# Altium schematic sheet Master1.SchDoc
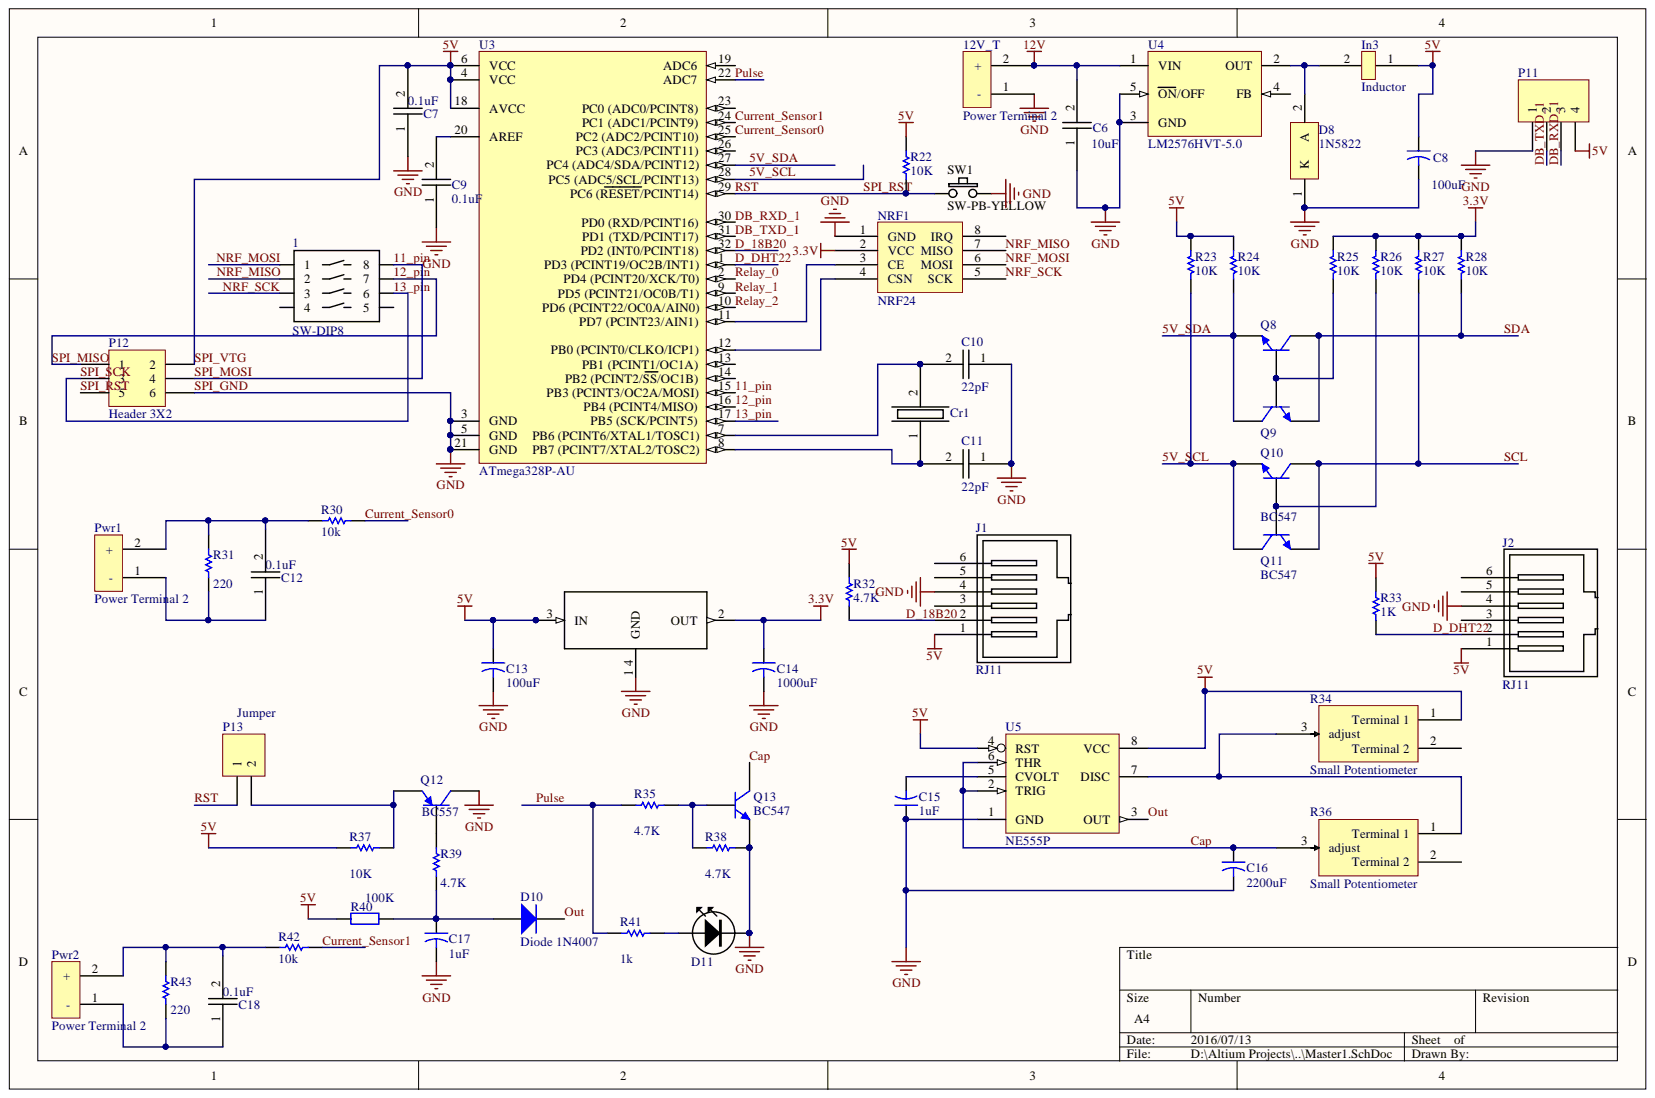
[diagram p0: Master1.SchDoc]

## Parts
1  SW-DIP8  [SW-DIP8]
2  AMS1117-3.3  [SOT-223_AMS1117-3.3(Primary)]  (value not drawn)
12V_T  Power Terminal 2  [PowerTerminal_2]
C6  value 10uF  [CAPNTO2.54-3.05x1.78A]  (hidden comment: Ceramic Capacitor)
C7  value 0.1uF  [CAPNTO2.54-3.05x1.78A]  (hidden comment: Ceramic Capacitor)
C8  value 100uF  [CAPR5-4X5]  (hidden comment: Cap2)
C9  value 0.1uF  [CAPNTO2.54-3.05x1.78A]  (hidden comment: Ceramic Capacitor)
C10  value 22pF  [CAPNTO2.54-3.05x1.78A]  (hidden comment: Ceramic Capacitor)
C11  value 22pF  [CAPNTO2.54-3.05x1.78A]  (hidden comment: Ceramic Capacitor)
C12  value 0.1uF  [CAPNTO2.54-3.05x1.78A]  (hidden comment: Ceramic Capacitor)
C13  value 100uF  [CAPR5-4X5]  (hidden comment: Cap2)
C14  value 1000uF  [CAPR5-4X5]  (hidden comment: Cap2)
C15  value 1uF  [CAPR5-4X5]  (hidden comment: Cap2)
C16  Cap2  value 2200uF  [CAPR5-4X5]
C17  value 1uF  [CAPR5-4X5]  (hidden comment: Cap2)
C18  value 0.1uF  [CAPNTO2.54-3.05x1.78A]  (hidden comment: Ceramic Capacitor)
Cr1  Crystal  [Crytal_HC49]  (value not drawn)
D8  1N5822  [ONSC-AXIAL_LEAD-2-267-05_V]
D10  Diode 1N4007  [DO-41]
D11  LED-GREEN-3MM  [LED-3MM-GREEN]  (value not drawn)
In3  Inductor  [Inductor]
J1  RJ11  [RJ11]
J2  RJ11  [RJ11]
NRF1  NRF24  [NRF24]
P11  Header 4  [HDR1X4]  (value not drawn)
P12  Header 3X2  [HDR2X3]
P13  Jumper  [HDR1X2]
Pwr1  Power Terminal 2  [PowerTerminal_2]
Pwr2  Power Terminal 2  [PowerTerminal_2]
Q8  BC547  [SOT54]  (value not drawn)
Q9  BC547  [SOT54]  (value not drawn)
Q10  BC547  [SOT54]
Q11  BC547  [SOT54]
Q12  BC557  [SOT54]
Q13  BC547  [SOT54]
R22  value 10K  [AXIAL-0.3]  (hidden comment: Res1)
R23  value 10K  [AXIAL-0.3]  (hidden comment: Res1)
R24  value 10K  [AXIAL-0.3]  (hidden comment: Res1)
R25  value 10K  [AXIAL-0.3]  (hidden comment: Res1)
R26  value 10K  [AXIAL-0.3]  (hidden comment: Res1)
R27  value 10K  [AXIAL-0.3]  (hidden comment: Res1)
R28  value 10K  [AXIAL-0.3]  (hidden comment: Res1)
R30  value 10k  [AXIAL-0.3]  (hidden comment: Res1)
R31  value 220  [AXIAL-0.3]  (hidden comment: Res1)
R32  value 4.7K  [AXIAL-0.3]  (hidden comment: Res1)
R33  value 1K  [AXIAL-0.3]  (hidden comment: Res1)
R34  Small Potentiometer  [Potentiometer]
R35  value 4.7K  [AXIAL-0.3]  (hidden comment: Res1)
R36  Small Potentiometer  [Potentiometer]
R37  value 10K  [AXIAL-0.3]  (hidden comment: Res1)
R38  value 4.7K  [AXIAL-0.3]  (hidden comment: Res1)
R39  value 4.7K  [AXIAL-0.3]  (hidden comment: Res1)
R40  value 100K  [AXIAL-0.4]  (hidden comment: Res2)
R41  value 1k  [AXIAL-0.3]  (hidden comment: Res1)
R42  value 10k  [AXIAL-0.3]  (hidden comment: Res1)
R43  value 220  [AXIAL-0.3]  (hidden comment: Res1)
SW1  SW-PB-YELLOW  [SW-PB-YELLOW-ROUND4]
U3  ATmega328P-AU  [32A_N]
U4  LM2576HVT-5.0  [T05A]
U5  NE555P  [P008]

## Nets
3.3V: 2.2 C14.1 NRF1.2(VCC) R25.2 R26.2 R27.2 R28.2
12V: 12V_T.2 C6.2 U4.1(VIN)
5V_SCL: Q10.1 Q11.3 R23.1 U3.28(PC5_(ADC5/SCL/PCINT13))
5V_SDA: Q8.1 Q9.3 R24.1 U3.27(PC4_(ADC4/SDA/PCINT12))
11_PIN: 1.8 P12.4 U3.15(PB3_(PCINT3/OC2A/MOSI))
12_PIN: 1.7 P12.1 U3.16(PB4_(PCINT4/MISO))
13_PIN: 1.6 P12.3 U3.17(PB5_(SCK/PCINT5))
CAP: C16.1 Q13.3 R36.3 U5.2(TRIG) U5.6(THR)
CURRENT_SENSOR0: R30.1 U3.25
CURRENT_SENSOR1: R42.1 U3.24(PC1_(ADC1/PCINT9))
D_18B20: J1.2 R32.2 U3.32(PD2_(INT0/PCINT18))
D_DHT22: J2.2 R33.2 U3.1(PD3_(PCINT19/OC2B/INT1))
DB_RXD_1: P11.3 U3.30(PD0_(RXD/PCINT16))
DB_TXD_1: P11.2 U3.31(PD1_(TXD/PCINT17))
NRF_MISO: 1.2 NRF1.7(MISO)
NRF_MOSI: 1.1 NRF1.6(MOSI)
NRF_SCK: 1.3 NRF1.5(SCK)
OUT: D10.2 U5.3(OUT)
PULSE: R35.1 R41.1 U3.22(ADC7)
RELAY_0: U3.2(PD4_(PCINT20/XCK/T0))
RELAY_1: U3.9(PD5_(PCINT21/OC0B/T1))
RELAY_2: U3.10(PD6_(PCINT22/OC0A/AIN0))
RST: P12.5 P13.1 R22.1 SW1.1 U3.29(PC6_(RESET/PCINT14))
SCL: Q10.3 Q11.1 R27.1
SDA: Q8.3 Q9.1 R28.1
SPI_GND: 12V_T.1 C6.1 C7.1 C8.2 C9.1 C10.1 C11.1 C13.2 C14.2 C15.1 C16.2 C17.2 D8.1 D11.K J1.4 J2.4 NRF1.1(GND) P11.1 P12.6 Q12.3 Q13.1 R38.2 SW1.2 U3.3(GND) U3.5(GND) U3.21(GND) U4.3(GND) U4.5(ON/OFF) U5.1(GND)
SPI_VTG: 2.3 C7.2 C8.1 C13.1 In3.1 J1.1 J2.1 P11.4 P12.2 R22.2 R23.2 R24.2 R32.1 R33.1 R34.1 R37.1 R40.1 U3.4(VCC) U3.6(VCC) U3.18(AVCC) U5.4(RST) U5.8(VCC)
NetC9_2: C9.2 U3.20(AREF)
NetC10_2: C10.2 Cr1.2 U3.7
NetC11_2: C11.2 Cr1.1 U3.8
NetC12_1: C12.1 Pwr1.1 R31.1
NetC12_2: C12.2 Pwr1.2 R30.2 R31.2
NetC15_2: C15.2 U5.5(CVOLT)
NetC17_1: C17.1 D10.1 R39.1 R40.2
NetC18_1: C18.1 Pwr2.1 R43.1
NetC18_2: C18.2 Pwr2.2 R42.2 R43.2
NetD8_2: D8.2 In3.2 U4.2(OUT)
NetD11_A: D11.A R41.2
NetNRF1_3: NRF1.3(CE) U3.11(PD7_(PCINT23/AIN1))
NetNRF1_4: NRF1.4(CSN) U3.12(PB0_(PCINT0/CLKO/ICP1))
NetP13_2: P13.2 Q12.1 R37.2
NetQ8_2: Q8.2 Q9.2 R25.1
NetQ10_2: Q10.2 Q11.2 R26.1
NetQ12_2: Q12.2 R39.2
NetQ13_2: Q13.2 R35.2 R38.1
NetR34_3: R34.3 R36.1 U5.7(DISC)

Not connected: 1 4 5; 2 1 4; J1 3 5 6; J2 3 5 6; NRF1 8(IRQ); R34 2; R36 2; U3 13(PB1_(PCINT1/OC1A)) 14(PB2_(PCINT2/SS/OC1B)) 19(ADC6) 23(PC0_(ADC0/PCINT8)) 26(PC3_(ADC3/PCINT11)); U4 4(FB)

## Library data of the parts
1 = SW-DIP8: 4 POLE DIP SWITCH; Component Kind: Standard; Library Name: vh_altium_library.intLib
C8 C13-C17 = Cap2: Capacitor; Component Kind: Standard; Library Name: Miscellaneous Devices.IntLib; PackageDescription: Capacitor; 2 Leads; PackageReference: CAPR5-4X5; Published: 23-Sep-2002; Signal Integrity: Cap; Simulation: CAP; Publisher: Altium Limited
D8 = 1N5822: Rectifier, 2-Pin Axial_Lead, Bulk Bag; Manufacturer: On Semiconductor; Configuration: Single; IFSM Max (A): 80; Note: 0; Component Kind: Standard; ComponentLink1Description: Manufacturer URL; ComponentLink1URL: http://www.onsemi.com/; ComponentLink2Description: Datasheet; ComponentLink2URL: http://www.onsemi.cn/pub_link/Collateral/1N5820-D.PDF; ComponentLink3Description: Package Specification; ComponentLink3URL: http://www.onsemi.com/pub_link/Collateral/267-05.PDF; DatasheetVersion: Rev. 10; Library Name: ON Semi Schottky Diodes & Schottky Rectifiers.IntLib; Mounting Technology: Through Hole; PackageDescription: 2-Pin, Axial Lead, Diameter 5.05 mm; PackageReference: AXIAL_LEAD-2-267-05; PackageVersion: Rev. G, 06/2000; Packing: Bulk Bag; PartNumber: 1N5822; RoHS: FALSE
D10 = Diode 1N4007: 1 Amp General Purpose Rectifier; Code_JEDEC: DO-204-AL; Component Kind: Standard; ComponentLink1Description: Manufacturer Link; ComponentLink1URL: http://www.fairchildsemi.com/; ComponentLink2Description: Datasheet; ComponentLink2URL: http://www.fairchildsemi.com/ds/1N%2F1N4001.pdf; DatasheetVersion: 1998; LatestRevisionDate: 17-Jul-2002; LatestRevisionNote: Re-released for DXP Platform.; Library Name: Miscellaneous Devices.IntLib; PackageDescription: Diode, Axial; Body 5.2 x 2.7 mm (LxDia. max), Lead Dia. 0.86 mm (max); PackageReference: DO-41; PackageVersion: Aug-1999; Published: 8-Jun-2000; Signal Integrity: 1N4007; Simulation: 1N4007; Publisher: Altium Limited
J1 J2 = RJ11: GENERIC RJ11 6 PIN CONNECTOR; Component Kind: Standard; Library Name: vh_altium_library.intLib
P11 = Header 4: Header, 4-Pin; Component Kind: Standard; LatestRevisionDate: 17-Jul-2002; LatestRevisionNote: Re-released for DXP Platform.; Library Name: Miscellaneous Connectors.IntLib; Signal Integrity: Connector; Publisher: Altium Limited
P12 = Header 3X2: Header, 3-Pin, Dual row; Component Kind: Standard; LatestRevisionDate: 17-Jul-2002; LatestRevisionNote: Re-released for DXP Platform.; Library Name: Miscellaneous Connectors.IntLib; Signal Integrity: Connector; Publisher: Altium Limited
P13 = Header 2: Header, 2-Pin; Component Kind: Standard; LatestRevisionDate: 17-Jul-2002; LatestRevisionNote: Re-released for DXP Platform.; Library Name: Miscellaneous Connectors.IntLib; Signal Integrity: Connector; Publisher: Altium Limited
Q8-Q11 Q13 = BC547: NPN General-purpose Transistor; Code_JEDEC: TO-92; Code_JEITA: SC-43; Component Kind: Standard; ComponentLink1Description: Manufacturer Link; ComponentLink1URL: http://www.semiconductors.philips.com/; ComponentLink2Description: Datasheet; ComponentLink2URL: http://www.semiconductors.philips.com/acrobat/datasheets/BC546_547_3.pdf; DatasheetVersion: 15-Apr-1999; LatestRevisionDate: 12-Dec-2006; LatestRevisionNote: Footprint pad sequence fixed.; Library Name: Philips Discrete BJT - Low Power.IntLib; PackageDescription: Plastic Single-Ended Leaded (through hole) package; 3 Leads (TO-92); PackageReference: SOT54; PackageVersion: Feb-1997; Published: 17-Sep-2003; Signal Integrity: BC547; Publisher: Altium Limited
Q12 = BC557: PNP General-purpose Transistor; Code_JEDEC: TO-92; Code_JEITA: SC-43; Component Kind: Standard; ComponentLink1Description: Manufacturer Link; ComponentLink1URL: http://www.semiconductors.philips.com/; ComponentLink2Description: Datasheet; ComponentLink2URL: http://www.semiconductors.philips.com/acrobat/datasheets/BC556_557_3.pdf; DatasheetVersion: 15-Apr-1999; LatestRevisionDate: 12-Dec-2006; LatestRevisionNote: Footprint pad sequence fixed.; Library Name: Philips Discrete BJT - Low Power.IntLib; PackageDescription: Plastic Single-Ended Leaded (through hole) package; 3 Leads (TO-92); PackageReference: SOT54; PackageVersion: Feb-1997; Published: 17-Sep-2003; Signal Integrity: BC557; Publisher: Altium Limited
R22-R28 R30-R33 R35 R37-R39 R41-R43 = Res1: Resistor; Component Kind: Standard; LatestRevisionDate: 17-Jul-2002; LatestRevisionNote: Re-released for DXP Platform.; Library Name: Miscellaneous Devices.IntLib; PackageDescription: Axial Device, Thru-Hole; 2 Leads; 0.3 in Pin Spacing; PackageReference: AXIAL-0.3; Published: 8-Jun-2000; Signal Integrity: Res; Simulation: RESISTOR; Publisher: Altium Limited
R40 = Res2: Resistor; Component Kind: Standard; LatestRevisionDate: 17-Jul-2002; LatestRevisionNote: Re-released for DXP Platform.; Library Name: Miscellaneous Devices.IntLib; PackageDescription: Axial Device, Thru-Hole; 2 Leads; 0.4 in Pin Spacing; PackageReference: AXIAL-0.4; Published: 8-Jun-2000; Signal Integrity: Res; Simulation: RESISTOR; Publisher: Altium Limited
SW1 = SW-PB-YELLOW: SW-D6-R / SW-DS-S / SW-14X14 / SW-7X7; Component Kind: Standard; Library Name: vh_altium_library.intLib
U3 = ATmega328P-AU: 8-bit AVR Microcontroller, 32KB Flash, 1KB EEPROM, 2KB SRAM, 32-pin TQFP, Industrial Grade (-40°C to 85°C); Code_JEDEC: MS-026-ABA; Component Kind: Standard; ComponentLink1Description: Manufacturer URL; ComponentLink1URL: http://www.atmel.com/; ComponentLink2Description: ATmega48A/48PA/88A/88PA/168A/168PA/328/328P Datasheet; ComponentLink2URL: http://www.atmel.com/dyn/resources/prod_documents/doc8271.pdf; ComponentLink3Description: ATmega48A/48PA/88A/88PA/168A/168PA/328/328P Summary; ComponentLink3URL: http://www.atmel.com/dyn/resources/prod_documents/8271S.pdf; DatasheetVersion: revD, May-2011; Library Name: Atmel Microcontroller megaAVR.IntLib; PackageDescription: 32-Lead, 7x7x1.0 mm Body, 0.8mm Lead Pitch, Thin Profile Plastic Quad Flat Package (TQFP); PackageReference: 32A; PackageVersion: revC, Oct-2010
U4 = LM2576HVT-5.0: SIMPLE SWITCHER® 3A Step-Down Voltage Regulator, 5-pin TO-220; Manufacturer: National Semiconductor; High-Reliability: Y; PackageTopMarking: NSUZXYTTE#*LM2576HVT*-5.0 P+; PowerWise: N; Code_JEDEC: TO-220-AB; Component Kind: Standard; ComponentLink1Description: Manufacturer URL; ComponentLink1URL: http://www.national.com/; ComponentLink2Description: LM2576HV Datasheet; ComponentLink2URL: http://www.national.com/ds/LM/LM2576HV.pdf; ComponentLink3Description: LM2576HV Web Page; ComponentLink3URL: http://www.national.com/pf/LM/LM2576HV.html; ComponentLink4Description: T05A Package Page; ComponentLink4URL: http://www.national.com/packaging/folders/t05a.html; CompType: Switcher; DatasheetVersion: Aug-2004; Library Name: National Semiconductor Switcher.IntLib; PackageDescription: TO-220, Molded, 5 Lead, Straight; PackageReference: T05A; PackageVersion: revJ, Jan-1992; PartNumber: LM2576HVT-5.0; PinCount: 5; RoHS: N
U5 = NE555P: Precision Timer; Code_JEDEC: MO-001-AN; Component Kind: Standard; ComponentLink1Description: Manufacturer Link; ComponentLink1URL: http://www.ti.com/; ComponentLink2Description: Datasheet; ComponentLink2URL: http://www-s.ti.com/sc/ds/ne555.pdf; DatasheetVersion: Feb-1992; LatestRevisionDate: 04-Mar-2005; LatestRevisionNote: Stylized 3D Model Added.; Library Name: TI Analog Timer Circuit.IntLib; PackageDescription: DIP; 8 Leads; Row Spacing 7.62 mm; Pitch 2.54 mm; PackageReference: P008; PackageVersion: Jun-1999; PCB3D: P008; Published: 16-Jan-2001; Publisher: Altium Limited
2 = AMS1117-3.3: Component Kind: Standard; Library Name: AMS1117-3.3.SchLib
12V_T Pwr1 Pwr2 = Power Terminal 2: Component Kind: Standard; Description: Power Terminal 2; Library Name: PWRTerminal2.SchLib
C6 C7 C9-C12 C18 = Ceramic Capacitor: Component Kind: Standard; Description: Ceramic Capacitor; Library Name: CerCapacitor.SchLib
Cr1 = Crystal: Component Kind: Standard; Description: Crystal; Library Name: Crystal.SchLib
D11 = LED-GREEN-3MM: Component Kind: Standard; Description: LED-GREEN-3MM; Library Name: vh_altium_library.intLib
In3 = Inductor: Component Kind: Standard; Description: Inductor; Library Name: Inductor.SchLib
NRF1 = NRF24: Component Kind: Standard; Description: NRF24; Library Name: NRF24.SchLib
R34 R36 = Small Potentiometer: Component Kind: Standard; Description: Small Potentiometer; Library Name: SPot.SchLib
